# Altium schematic sheet DC_DC.SchDoc
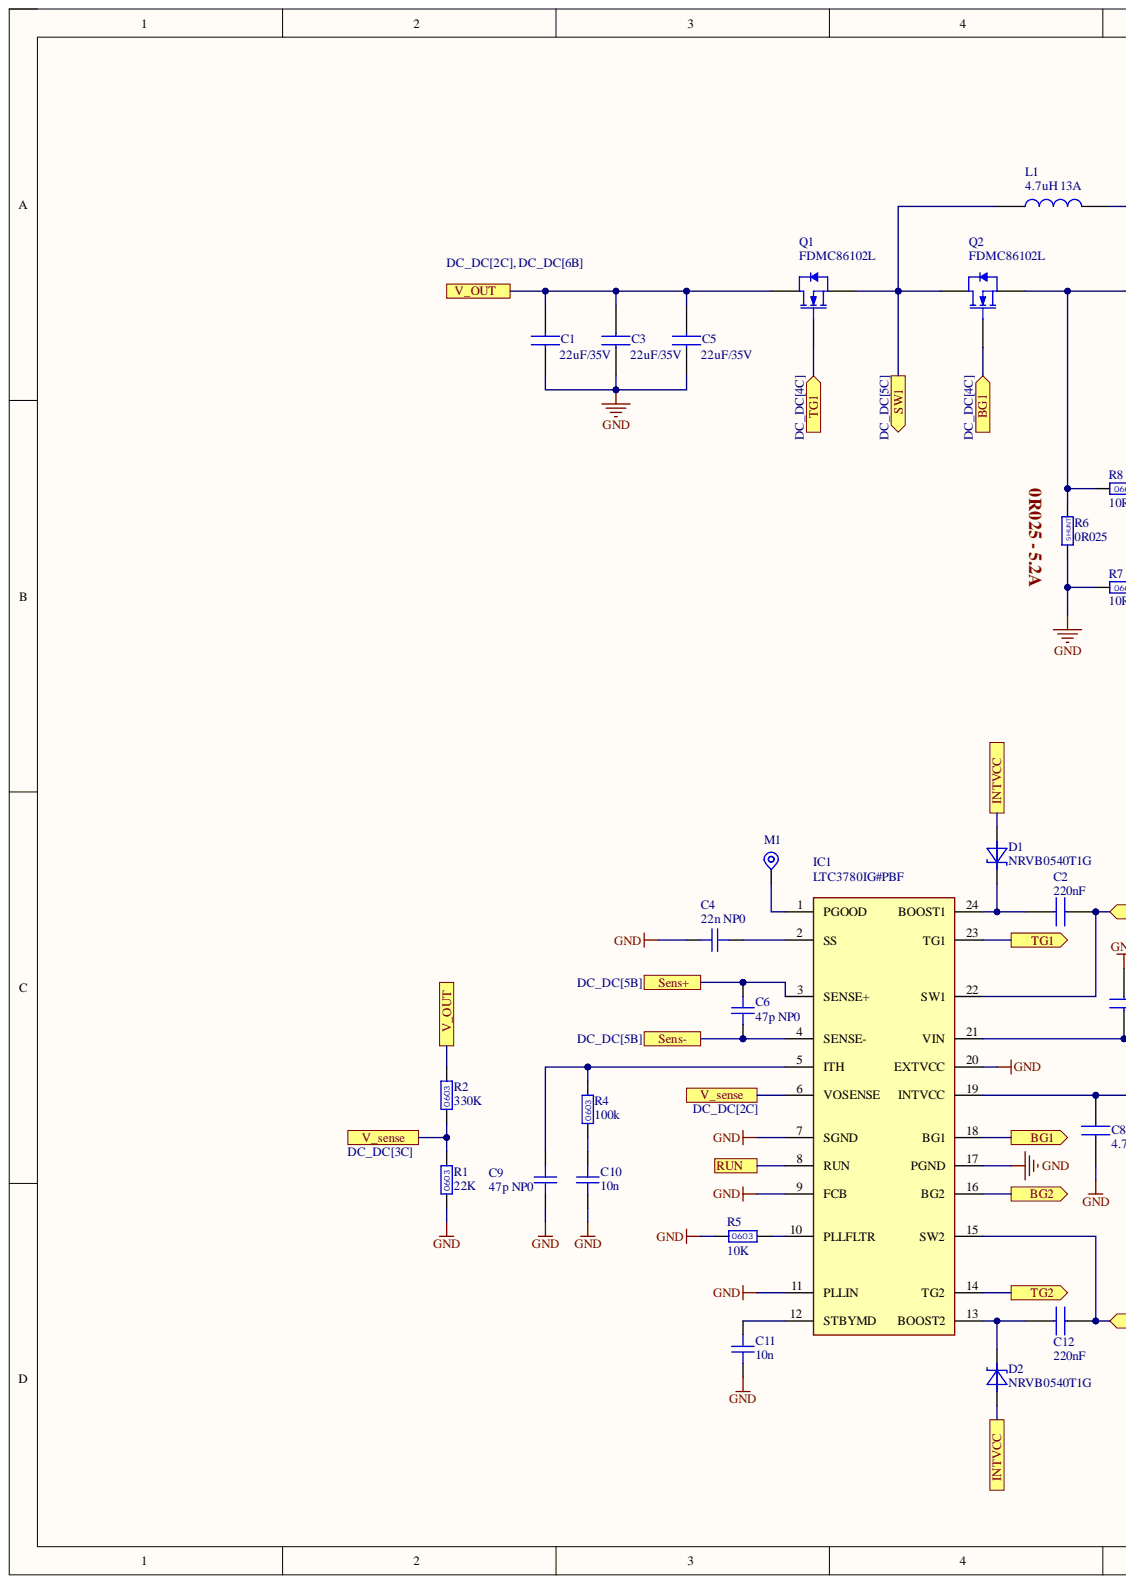
[diagram p0: DC_DC.SchDoc, left]
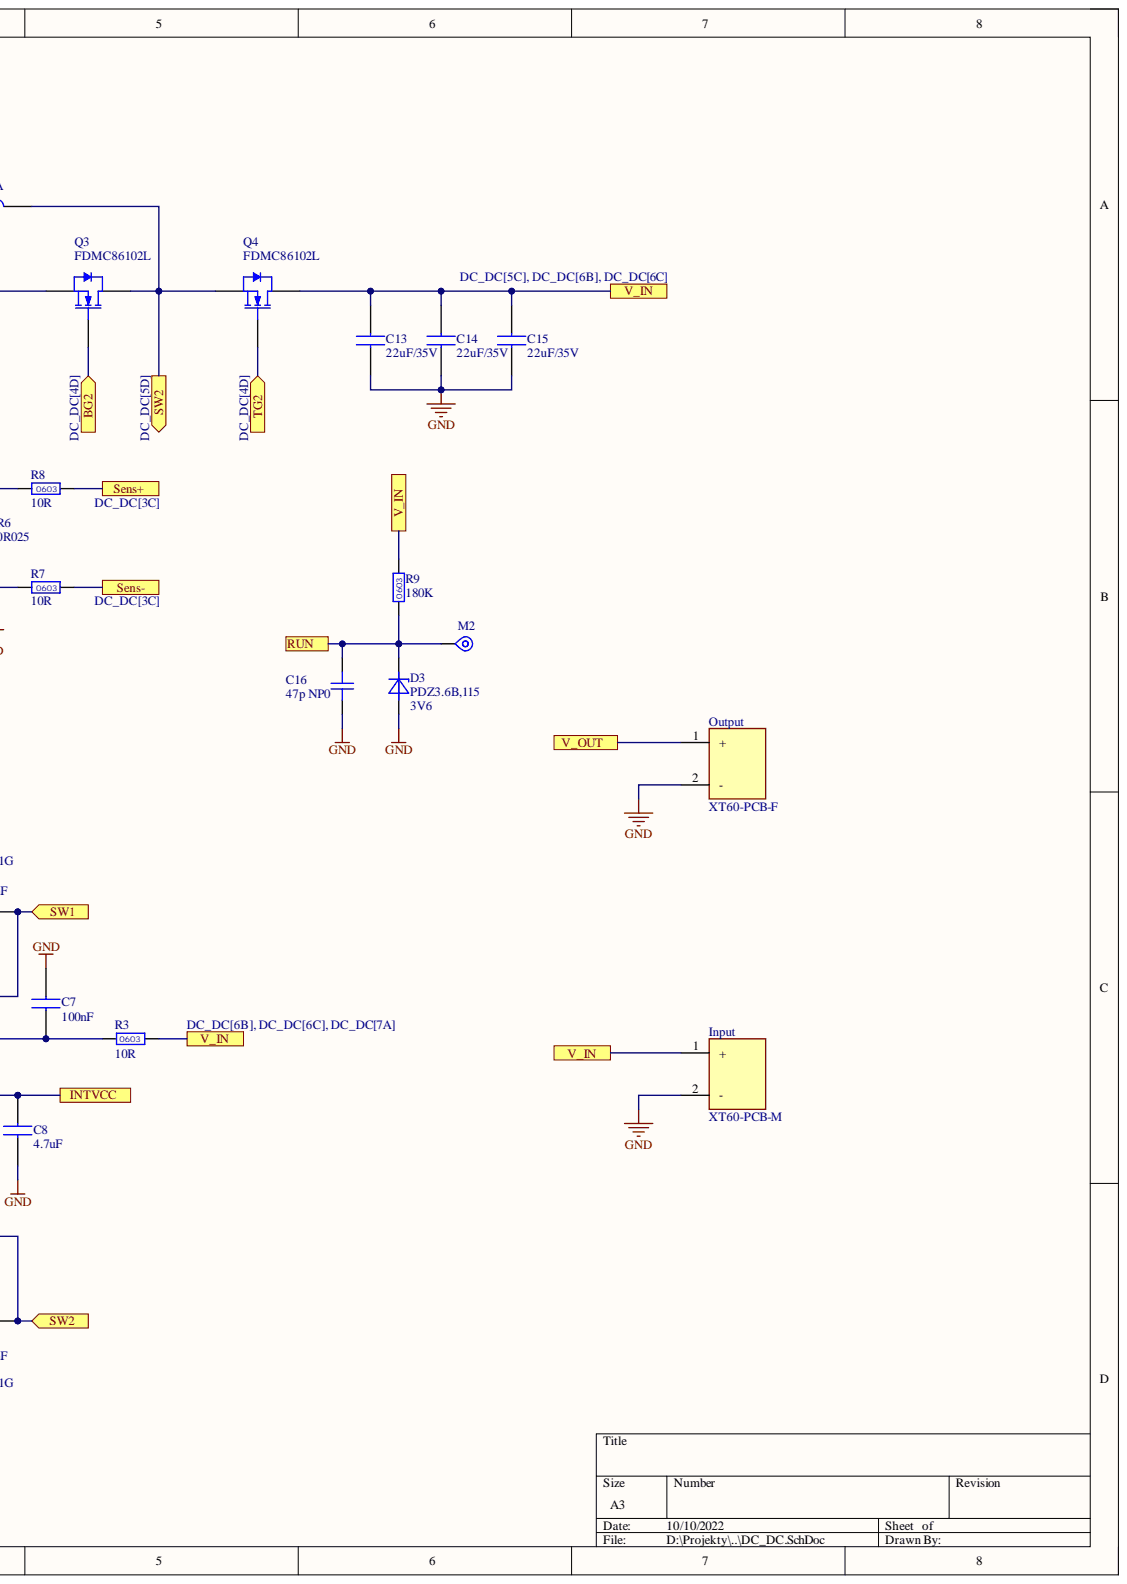
[diagram p1: DC_DC.SchDoc, right]

## Parts
C1  value 22uF/35V  [C3216_Commercial]  (hidden comment: C3216X5R1V226M160AC)
C2  value 220nF  [C3216_Commercial]  (hidden comment: C1206C224J1RACAUTO)
C3  value 22uF/35V  [C3216_Commercial]  (hidden comment: C3216X5R1V226M160AC)
C4  value 22n NP0  [C0603]  (hidden comment: AC0603KRX7R9BB223)
C5  value 22uF/35V  [C3216_Commercial]  (hidden comment: C3216X5R1V226M160AC)
C6  value 47p NP0  [C0603]  (hidden comment: GCM1885C2A470JA16J)
C7  value 100nF  [C3216_Commercial]  (hidden comment: GRT31C5C2A104JA12L)
C8  value 4.7uF  [C3216_Commercial]  (hidden comment: GRM31CC72A475ME11L)
C9  value 47p NP0  [C0603]  (hidden comment: GCM1885C2A470JA16J)
C10  value 10n  [C0603]  (hidden comment: C0603X103K1RAC3316)
C11  value 10n  [C0603]  (hidden comment: C0603X103K1RAC3316)
C12  value 220nF  [C3216_Commercial]  (hidden comment: C1206C224J1RACAUTO)
C13  value 22uF/35V  [C3216_Commercial]  (hidden comment: C3216X5R1V226M160AC)
C14  value 22uF/35V  [C3216_Commercial]  (hidden comment: C3216X5R1V226M160AC)
C15  value 22uF/35V  [C3216_Commercial]  (hidden comment: C3216X5R1V226M160AC)
C16  value 47p NP0  [C0603]  (hidden comment: GCM1885C2A470JA16J)
D1  NRVB0540T1G  [SOD323]
D2  NRVB0540T1G  [SOD323]
D3  PDZ3.6B,115  value 3V6  [SOD323]
IC1  LTC3780IG#PBF  [SOP65P780X200-24N]
Input  XT60-PCB-M  [XT60-PCB-M]
L1  value 4.7uH 13A  [WE-HCI]  (hidden comment: 7443551470)
M1  TP  [Pad 1mm SMD]  (value not drawn)  (no BOM)
M2  TP  [Pad 1mm SMD]  (value not drawn)  (no BOM)
Output  XT60-PCB-F  [XT60-PCB-F]
Q1  FDMC86102L  [PowerPak1212_LFPAK33]
Q2  FDMC86102L  [PowerPak1212_LFPAK33]
Q3  FDMC86102L  [PowerPak1212_LFPAK33]
Q4  FDMC86102L  [PowerPak1212_LFPAK33]
R1  value 22K  [R0603]  (hidden comment: RC0603JR-0722KL)
R2  value 330K  [R0603]  (hidden comment: CRGCQ0603J330K)
R3  value 10R  [R0603]  (hidden comment: CR0603-FX-10R0ELF)
R4  value 100k  [R0603]  (hidden comment: RC0603FR-07100KL)
R5  value 10K  [R0603]  (hidden comment: CRGP0603F10K)
R6  value 0R025  [Shunt_2512]  (hidden comment: CS121WF250MT2E)
R7  value 10R  [R0603]  (hidden comment: CR0603-FX-10R0ELF)
R8  value 10R  [R0603]  (hidden comment: CR0603-FX-10R0ELF)
R9  value 180K  [R0603]  (hidden comment: CRGCQ0603F180K)

## Ports
BG1, BG2, INTVCC, RUN, Sens+, Sens-, SW1, SW2, TG1, TG2, V_IN, V_OUT, V_sense

## Nets
GND: C1.1 C3.1 C4.1 C5.1 C7.2 C8.2 C9.1 C10.1 C11.2 C13.1 C14.1 C15.1 C16.1 D3.1 IC1.7(SGND) IC1.9(FCB) IC1.11(PLLIN) IC1.17(PGND) IC1.20(EXTVCC) Input.2 Output.2 R1.2 R5.2 R6.1 R7.1
BG1: IC1.18(BG1) Q2.4 ; port BG1
BG2: IC1.16(BG2) Q3.4 ; port BG2
INTVCC: C8.1 D1.1 D2.1 IC1.19(INTVCC) ; port INTVCC
RUN: C16.2 D3.2 IC1.8(RUN) M2.1 R9.2 ; port RUN
SW1: C2.2 IC1.22(SW1) L1.1 Q1.3 Q2.5 ; port SW1
SW2: C12.2 IC1.15(SW2) L1.2 Q3.5 Q4.3 ; port SW2
TG1: IC1.23(TG1) Q1.4 ; port TG1
TG2: IC1.14(TG2) Q4.4 ; port TG2
V_IN: C13.2 C14.2 C15.2 Input.1 Q4.5 R3.1 R9.1 ; port V_IN
V_OUT: C1.2 C3.2 C5.2 Output.1 Q1.5 R2.1 ; port V_OUT
V_sense: IC1.6(VOSENSE) R1.1 R2.2 ; port V_sense
NetC2_1: C2.1 D1.2 IC1.24(BOOST1)
NetC4_2: C4.2 IC1.2(SS)
NetC6_1: C6.1 IC1.4(SENSE-) R7.2
NetC6_2: C6.2 IC1.3(SENSE+) R8.2
NetC7_1: C7.1 IC1.21(VIN) R3.2
NetC9_2: C9.2 IC1.5(ITH) R4.1
NetC10_2: C10.2 R4.2
NetC11_1: C11.1 IC1.12(STBYMD)
NetC12_1: C12.1 D2.2 IC1.13(BOOST2)
NetIC1_1: IC1.1(PGOOD) M1.1
NetIC1_10: IC1.10(PLLFLTR) R5.1
NetQ2_3: Q2.3 Q3.3 R6.2 R8.1

## Library data of the parts
C1 C3 C5 C13-C15 = CC_1206_X5R_226: Capacitor X5R; Manufacturer_Name: TDK; Arrow Part Number: C3216X5R1V226M160AC; Arrow Price/Stock: https://www.arrow.com/en/products/c3216x5r1v226m160ac/tdk; Component Kind: Standard; Datasheet Link: https://product.tdk.com/system/files/dam/doc/product/capacitor/ceramic/mlcc/catalog/mlcc_commercial_general_en.pdf; Height: 0.75mm; Library Name: CapRes.IntLib; Manufacturer_Part_Number: C3216X5R1V226M160AC; Mouser Part Number: 810-C3216X5R1V226M; Mouser Price/Stock: https://www.mouser.co.uk/ProductDetail/TDK/C3216X5R1V226M160AC?qs=NRhsANhppD8TwN31eZ1xGQ%3D%3D; Supplier 1: Mouser; Supplier 2: Farnell; Supplier 3: TME; Supplier Part Number 1: 810-C3216X5R1V226M; Supplier Part Number 2: 2525173; Supplier Part Number 3: C3216X5R1V226MAC
C2 C12 = CC_1206_X7R_224: Capacitor X7R; Component Kind: Standard; Library Name: CapRes.IntLib; Supplier 1: Mouser; Supplier 2: Digi-Key; Supplier Part Number 1: 80-C1206C224J1RAUTO; Supplier Part Number 2: 399-C1206C224J1RACAUTOCT-ND
C4 = CC_0603_X7R_223: Ceramic Capacitor NP0 50V; Manufacturer Part Number: 22n/50V X7R C0603; Main: Capacitors; SMD/THD: SMD; Category: Capacitors CERA SMD; Component Kind: Standard; Component Type: Capacitors; Library Name: CapRes.IntLib; Part Number: 22n/50V X7R C0603; Status: A; Supplier 1: Mouser; Supplier 2: Farnell; Supplier 3: Digi-Key; Supplier Part Number 1: 603-AC603KRX7R9BB223; Supplier Part Number 2: 3368998; Supplier Part Number 3: 311-3119-6-ND
C6 = CC_0603_NP0_470: Ceramic Capacitor NP0; Main: Capacitors; SMD/THD: SMD; Value: 47p NP0; Category: Capacitors CERA SMD; Component Kind: Standard; Component Type: Capacitors; ComponentLink1Description: datasheet; Library Name: CapRes.IntLib; Status: A; Supplier 1: Mouser; Supplier 2: Farnell; Supplier Part Number 1: 81-GCM1885C2A470JA6J; Supplier Part Number 2: 3784365
C7 = CC_1206_NP0_104: Capacitor NP0; Component Kind: Standard; Library Name: CapRes.IntLib; Supplier 1: Mouser; Supplier 2: Digi-Key; Supplier Part Number 1: 81-GRT31C5C2A104JA2L; Supplier Part Number 2: 490-GRT31C5C2A104JA12LDKR-ND
C8 = CC_1206_X7S_475: Capacitor X7S; Component Kind: Standard; Library Name: CapRes.IntLib; Supplier 1: Mouser; Supplier 2: Digi-Key; Supplier Part Number 1: 81-GRM31CC72A475ME11; Supplier Part Number 2: 490-18621-6-ND
C9 C16 = CC_0603_NP0_470: Ceramic Capacitor NP0; Main: Capacitors; SMD/THD: SMD; Category: Capacitors CERA SMD; Component Kind: Standard; Component Type: Capacitors; ComponentLink1Description: datasheet; Library Name: CapRes.IntLib; Status: A; Supplier 1: Mouser; Supplier 2: Farnell; Supplier Part Number 1: 81-GCM1885C2A470JA6J; Supplier Part Number 2: 3784365
C10 C11 = CC_0603_X7R_103: Ceramic Capacitor X7R 100V; Part Number: 10n/100V X7R C0603; Main: Capacitors; SMD/THD: SMD; Category: Capacitors CERA SMD; Component Kind: Standard; Component Type: Capacitors; Library Name: CapRes.IntLib; Status: A; Supplier 1: Mouser; Supplier 2: Digi-Key; Supplier 3: Farnell; Supplier 4: TME; Supplier Part Number 1: 80-C0603X103K1R3316; Supplier Part Number 2: 399-C0603X103K1RAC3316CT-ND; Supplier Part Number 3: 2666889; Supplier Part Number 4: C0603X103K1RAC3316
D1 D2 = NRVB0540T1G: Schottky Diode 40V, 500mA; Main: Diodes; SMD/THD: SMD; Category: Schottky; Component Kind: Standard; Library Name: Semi.IntLib; Status: A; Supplier 1: Mouser; Supplier 2: Farnell; Supplier Part Number 1: 863-NRVB0540T1G; Supplier Part Number 2: 2723913; Value: NRVB0540T1G
D3 = PDZ3.6B,115: Zener Diode 3.6V, 400mW; Main: Diodes; SMD/THD: SMD; Category: Zener; Component Kind: Standard; Library Name: Semi.IntLib; Status: A; Supplier 1: Mouser; Supplier 2: TME; Supplier Part Number 1: 771-PDZ36B8115; Supplier Part Number 2: PDZ3.6B.115
IC1 = LTC3780IG#PBF: DC/DC Buck-Boost; Manufacturer_Name: Analog Devices; Component Kind: Standard; Height: 2mm; Library Name: Semi.IntLib; Manufacturer_Part_Number: LTC3780IG#PBF; Supplier 1: Mouser; Supplier 2: TME; Supplier Part Number 1: 584-LTC3780IG#PBF; Supplier Part Number 2: LTC3780IGPBF
Input = XT60-PCB-M: XT60 Connector; Manufacturer_Name: Amass; Manufacturer_Part_Number: XT30PB; Component Kind: Standard; Datasheet Link: https://www.tme.eu/Document/4acc913878197f8c2e30d4b8cdc47230/XT30UPB%20SPEC.pdf; Height: 10.7mm; Library Name: CapRes.IntLib
L1 = WE-HCI High Current 4.7uH-13A: WE-HCI SMT High Current Inductor 4.7uH 20% 100kHz Ferrite 13A SMD T/R   Frequency - Self Resonant 30MHz; Component Kind: Standard; Library Name: CapRes.IntLib
M1 M2 = TP_PCB: Test Point; Main: Mechanical; Category: Pads_Pins_Measure_Points; Component Type: Mechanical; Library Name: CapRes.IntLib; Stock number: --------
Output = XT60-PCB-F: XT60 Connector; Manufacturer_Name: Amass; Manufacturer_Part_Number: XT30PB; Component Kind: Standard; Datasheet Link: https://www.tme.eu/Document/4acc913878197f8c2e30d4b8cdc47230/XT30UPB%20SPEC.pdf; Height: 10.7mm; Library Name: CapRes.IntLib
Q1-Q4 = FDMC86102L: N-MOS 100V, 18A, 23mOhm; Manufacturer: Fairchild; Main: Transistors; SMD/THD: SMD; Category: N-MOS; Component Kind: Standard; Component Type: Transistors; ComponentLink1Description: Datasheet; ComponentLink1URL: http://www.farnell.com/datasheets/1837750.pdf; Library Name: Semi.IntLib; Manufacturer Part Number: FDMC86102L; Part Number: FDMC86102L; Status: A; Value: FDMC86102L
R1 = CR_0603_223: Resistor 1%, 0.1W, 75V; Manufacturer: Vishay; Part Number: 22K R0603; Main: Resistors; SMD/THD: SMD; Category: Resistors SMD; Component Kind: Standard; Component Type: Resistors; Library Name: CapRes.IntLib; Status: A; Supplier 1: TME; Supplier 2: Farnell; Supplier 3: Digi-Key; Supplier Part Number 1: RC0603JR-0722K; Supplier Part Number 2: 9233547; Supplier Part Number 3: 311-22KGRCT-ND
R2 = CR_0603_334: Resistor 1%, 0.1W, 75V; Main: Resistors; SMD/THD: SMD; Category: Resistors SMD; Component Kind: Standard; Component Type: Resistors; Library Name: CapRes.IntLib; Status: A; Supplier 1: Mouser; Supplier 2: Digi-Key; Supplier 3: Farnell; Supplier Part Number 1: 279-CRGCQ0603J330K; Supplier Part Number 2: A130106CT-ND; Supplier Part Number 3: 2861879
R3 R7 R8 = CR_0603_100: Resistor 1%, 0.1W, 75V; Main: Resistors; SMD/THD: SMD; Category: Resistors SMD; Component Kind: Standard; Component Type: Resistors; Library Name: CapRes.IntLib; Status: A; Supplier 1: Mouser; Supplier 2: Farnell; Supplier 3: Digi-Key; Supplier Part Number 1: 652-CR0603FX-10R0ELF; Supplier Part Number 2: 2321756; Supplier Part Number 3: CR0603-FX-10R0ELFDKR-ND
R4 = CR_0603_104: Resistor 1%, 0.1W, 75V; Main: Resistors; SMD/THD: SMD; Category: Resistors SMD; Component Kind: Standard; Component Type: Resistors; Library Name: CapRes.IntLib; Status: A; Supplier 1: Mouser; Supplier 2: Farnell; Supplier 3: TME; Supplier Part Number 1: 603-RC0603FR-07100KL; Supplier Part Number 2: 9238727; Supplier Part Number 3: RC0603FR-07100K
R5 = CR_0603_103: Resistor 1%, 0.1W, 75V; Main: Resistors; SMD/THD: SMD; Category: Resistors SMD; Component Kind: Standard; Component Type: Resistors; Library Name: CapRes.IntLib; Status: A; Supplier 1: Mouser; Supplier 2: Digi-Key; Supplier 3: Farnell; Supplier Part Number 1: 279-CRGP0603F10K; Supplier Part Number 2: A130428CT-ND; Supplier Part Number 3: 2861046
R6 = SHUNT_2512_0R025: Current Sense Resistor 1%, 1W; Main: Resistors; SMD/THD: SMD; Category: Resistors SMD; Component Kind: Standard; Component Type: Resistors; Library Name: CapRes.IntLib; Status: A; Supplier 1: TME; Supplier Part Number 1: CS2512N4-0R025-1%
R9 = CR_0603_184: Resistor 1%, 0.1W, 75V; Main: Resistors; SMD/THD: SMD; Category: Resistors SMD; Component Kind: Standard; Component Type: Resistors; Library Name: CapRes.IntLib; Status: A; Supplier 1: Mouser; Supplier 2: Digi-Key; Supplier 3: Farnell; Supplier Part Number 1: 279-CRGCQ0603F180K; Supplier Part Number 2: A129715CT-ND; Supplier Part Number 3: 2861488
